# Altium schematic sheet schematic_B.SchDoc(schematic_B)
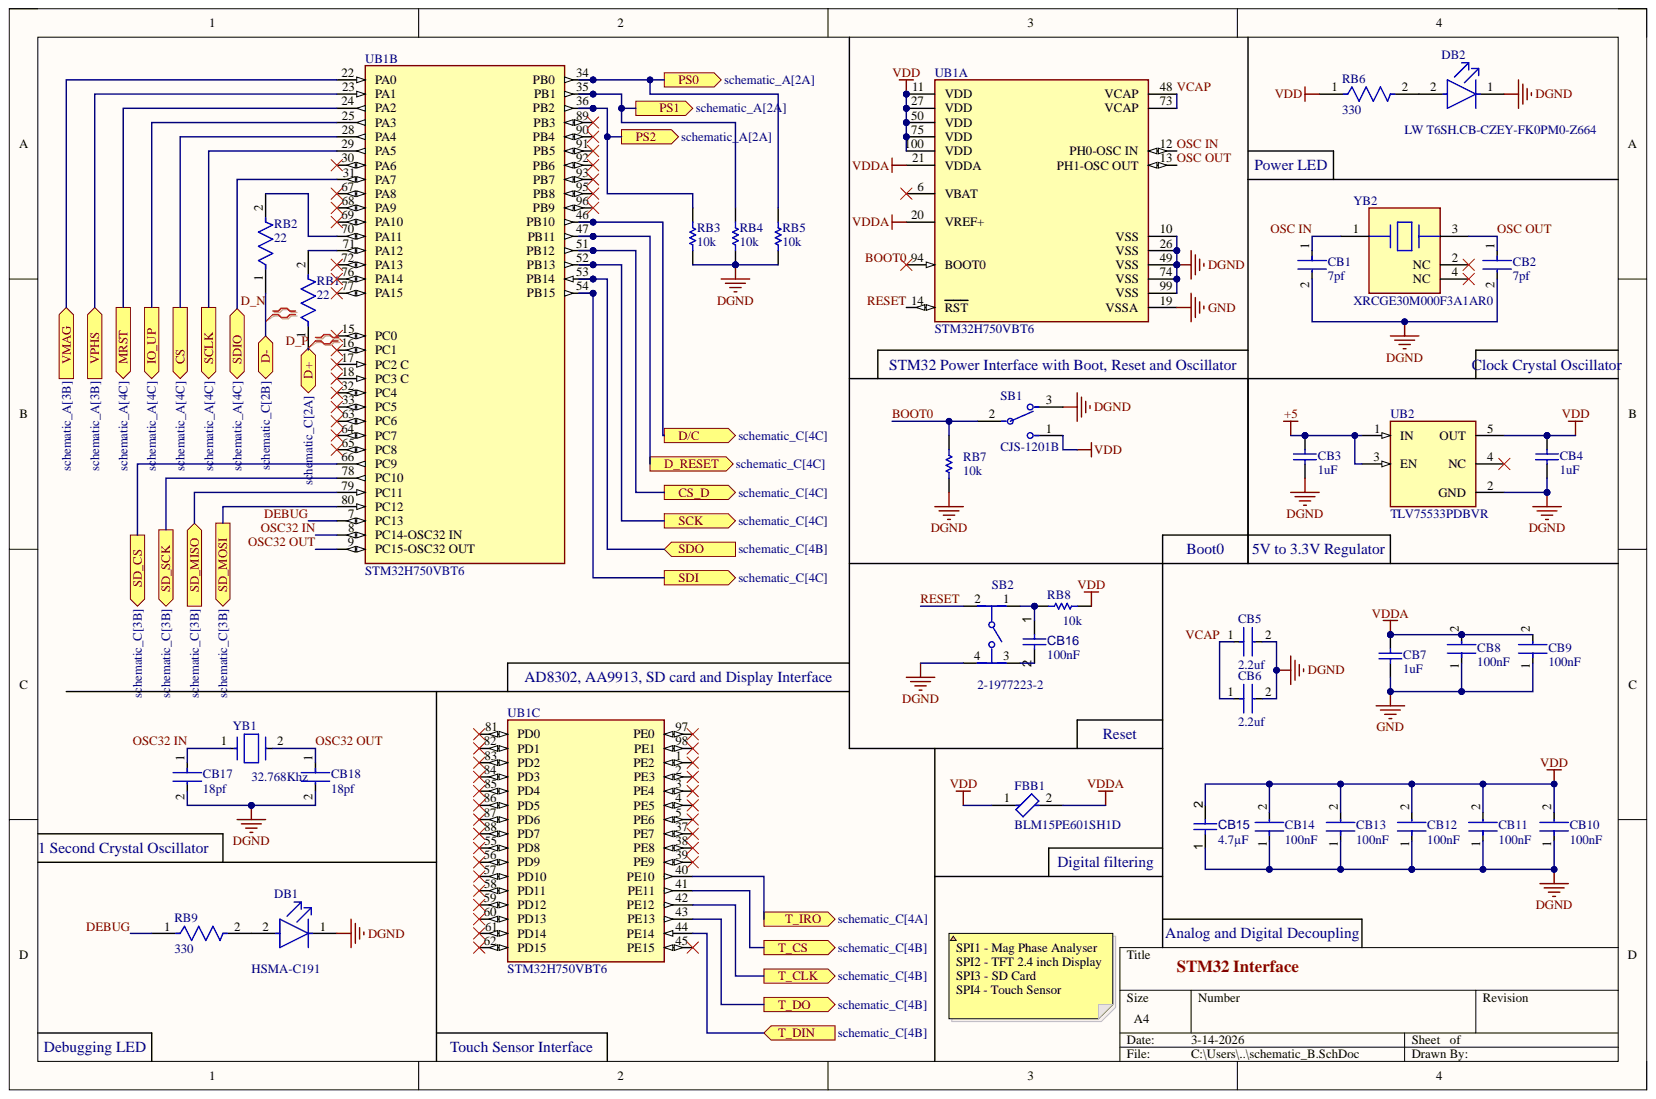
[diagram p0: schematic_B.SchDoc]

## Parts
CB1  7pf  [FP-GRM033-0_03-IPC_B]
CB2  7pf  [FP-GRM033-0_03-IPC_B]
CB3  1uF  [CAPC0603X33X15NL03T05]
CB4  1uF  [CAPC0603X33X15NL03T05]
CB5  2.2uf  [FP-GRM155-0_2-IPC_B]
CB6  2.2uf  [FP-GRM155-0_2-IPC_B]
CB7  1uF  [CAPC0603X33X15NL03T05]
CB8  100nF  [FP-0402-L_1_0_0_05-W_0_5-MFG]
CB9  100nF  [FP-0402-L_1_0_0_05-W_0_5-MFG]
CB10  100nF  [FP-0402-L_1_0_0_05-W_0_5-MFG]
CB11  100nF  [FP-0402-L_1_0_0_05-W_0_5-MFG]
CB12  100nF  [FP-0402-L_1_0_0_05-W_0_5-MFG]
CB13  100nF  [FP-0402-L_1_0_0_05-W_0_5-MFG]
CB14  100nF  [FP-0402-L_1_0_0_05-W_0_5-MFG]
CB15  value 4.7µF  [CAPC0805(2012)145_L]  (hidden comment: Capacitor 4.7µF +/-40% 25V 0805)
CB16  value 100nF  [CAPC0402(1005)60_L]  (hidden comment: Capacitor 100nF +/-20% 25V 0402)
CB17  18pf  [FP-GRM033-0_03-IPC_A]
CB18  18pf  [FP-GRM033-0_03-IPC_A]
DB1  HSMA-C191  [FP-HSMA-C191-MFG]
DB2  LW T6SH.CB-CZEY-FK0PM0-Z664  [FP-LW_T6SH_CB-CZEY-FK0PM0-MFG]
FBB1  BLM15PE601SH1D  [FP-BLM15-0_05-MFG]
RB1  22  [FP-RMCF0402-MFG]
RB2  22  [FP-RMCF0402-MFG]
RB3  10k  [RESC1005X40X25LL10T10]
RB4  10k  [RESC1005X40X25LL10T10]
RB5  10k  [RESC1005X40X25LL10T10]
RB6  330  [FP-RMCF0805-IPC_C]
RB7  10k  [RESC1005X40X25LL10T10]
RB8  10k  [RESC1005X40X25LL10T10]
RB9  330  [FP-RMCF0805-IPC_C]
SB1  CJS-1201B  [CJS-1201B_MFG]
SB2  2-1977223-2  [FP-2-1977223-2-MFG]
UB1  STM32H750VBT6  [FP-LQFP100-1L-MFG]  (parts A,B,C)
UB2  TLV75533PDBVR  [FP-DBV0005A-MFG]
YB1  32.768Khz  [FP-ABS09-MFG]
YB2  XRCGE30M000F3A1AR0  [FP-XRCGE-F-A_nbr-MFG]

## Ports
CS, CS_D, D+, D-, D/C, D_RESET, IO_UP, MRST, PS0, PS1, PS2, SCK, SCLK, SD_CS, SD_MISO, SD_MOSI, SD_SCK, SDI, SDIO, SDO, T_CLK, T_CS, T_DIN, T_DO, T_IRO, VMAG, VPHS

## Nets
+5: CB3.1 UB2.1(IN) UB2.3(EN)
DGND: CB1.2 CB2.2 CB3.2 CB4.2 CB5.2 CB6.2 CB10.1 CB11.1 CB12.1 CB13.1 CB14.1 CB15.1 CB17.2 CB18.2 DB1.1 DB2.1 RB3.2 RB4.2 RB5.2 RB7.1 SB1.3 SB2.4 UB1.10(VSS) UB1.26(VSS) UB1.49(VSS) UB1.74(VSS) UB1.99(VSS) UB2.2(GND)
GND: CB7.2 CB8.1 CB9.1 UB1.19(VSSA)
VDD: CB4.1 CB10.2 CB11.2 CB12.2 CB13.2 CB14.2 CB15.2 FBB1.1 RB6.1 RB8.1 SB1.1 UB1.11(VDD) UB1.27(VDD) UB1.50(VDD) UB1.75(VDD) UB1.100(VDD) UB2.5(OUT)
VDDA: CB7.1 CB8.2 CB9.2 FBB1.2 UB1.20(VREF+) UB1.21(VDDA)
BOOT0: RB7.2 SB1.2 UB1.94(BOOT0)
CS: UB1.28(PA4) ; port CS
CS_D: UB1.51(PB12) ; port CS_D
D/C: UB1.46(PB10) ; port D/C
D_N: RB2.1
D_P: RB1.1
D_RESET: UB1.47(PB11) ; port D_RESET
DEBUG: RB9.1 UB1.7(PC13)
IO_UP: UB1.25(PA3) ; port IO_UP
MRST: UB1.24(PA2) ; port MRST
OSC32 IN: CB17.1 UB1.8(PC14-OSC32_IN) YB1.1
OSC32 OUT: CB18.1 UB1.9(PC15-OSC32_OUT) YB1.2
OSC IN: CB1.1 UB1.12(PH0-OSC_IN) YB2.1
OSC OUT: CB2.1 UB1.13(PH1-OSC_OUT) YB2.3
PS0: RB5.1 UB1.34(PB0) ; port PS0
PS1: RB4.1 UB1.35(PB1) ; port PS1
PS2: RB3.1 UB1.36(PB2) ; port PS2
RESET: SB2.2 UB1.14(RST)
SCK: UB1.52(PB13) ; port SCK
SCLK: UB1.29(PA5) ; port SCLK
SD_CS: UB1.66(PC9) ; port SD_CS
SD_MISO: UB1.79(PC11) ; port SD_MISO
SD_MOSI: UB1.80(PC12) ; port SD_MOSI
SD_SCK: UB1.78(PC10) ; port SD_SCK
SDI: UB1.54(PB15) ; port SDI
SDIO: UB1.31(PA7) ; port SDIO
SDO: UB1.53(PB14) ; port SDO
T_CLK: UB1.42(PE12) ; port T_CLK
T_CS: UB1.41(PE11) ; port T_CS
T_DIN: UB1.44(PE14) ; port T_DIN
T_DO: UB1.43(PE13) ; port T_DO
T_IRO: UB1.40(PE10) ; port T_IRO
VCAP: CB5.1 CB6.1 UB1.48(VCAP) UB1.73(VCAP)
VMAG: UB1.22(PA0) ; port VMAG
VPHS: UB1.23(PA1) ; port VPHS
NetCB16_1: CB16.1 RB8.2 SB2.1
NetCB16_2: CB16.2 SB2.3
NetDB1_2: DB1.2 RB9.2
NetDB2_2: DB2.2 RB6.2
NetRB1_2: RB1.2 UB1.71(PA12)
NetRB2_2: RB2.2 UB1.70(PA11)

Not connected: UB1 1(PE2) 2(PE3) 3(PE4) 4(PE5) 5(PE6) 6(VBAT) 15(PC0) 16(PC1) 17(PC2_C) 18(PC3_C) 30(PA6) 32(PC4) 33(PC5) 37(PE7) 38(PE8) 39(PE9) 45(PE15) 55(PD8) 56(PD9) 57(PD10) 58(PD11) 59(PD12) 60(PD13) 61(PD14) 62(PD15) 63(PC6) 64(PC7) 65(PC8) 67(PA8) 68(PA9) 69(PA10) 72(PA13) 76(PA14) 77(PA15) 81(PD0) 82(PD1) 83(PD2) 84(PD3) 85(PD4) 86(PD5) 87(PD6) 88(PD7) 89(PB3) 90(PB4) 91(PB5) 92(PB6) 93(PB7) 95(PB8) 96(PB9) 97(PE0) 98(PE1); UB2 4(NC); YB2 2(NC) 4(NC)

## Library data of the parts
CB1 CB2 = CMP-2010-01441-2: Chip Multilayer Ceramic Capacitors for General Purpose, 0201, 7.0pF, C0G, 30ppm/°C, 0.1pF, 25V; Capacitance: 7pF; Case Code (Imperial): 0201; Case Code (Metric): 0603; Case/Package: 0201; Component Kind: Standard; Dielectric: C0G; Height: 0.3mm; Length: 0.024inch; Library Name: Altium Content Vault; Max Operating Temperature: 125°C; Min Operating Temperature: -55°C; Mount: Surface Mount; Package Quantity: 50000; Packaging: Tape and Reel; Termination: SMD/SMT; Thickness: 0.013inch; Voltage Rating: 25V; Voltage Rating (DC): 25V; Weight: 6E-06oz; Width: 0.012inch
CB3 CB4 CB7 = CMP-2010-00160-1: Manufacturer: AVX Interconnect / Elco; Part Number: 02016D105KAT2A; Component Kind: Standard; Library Name: Altium Content Vault
CB5 CB6 = CMP-06035-053544-1: Chip Multilayer Ceramic Capacitors for General Purpose, 0402, 2.2uF, X7R, 15%, 10%, 10V; Component Kind: Standard; Library Name: Altium Content Vault
CB8-CB14 = CMP-14477-003271-3: Ceramic Capacitor General Use 0.1uF ±10% 25V X5R 0402; NexarPartId: 49252556; Capacitance: 100nF; Case/Package: 0402; Component Kind: Standard; Halogen Free: Halogen Free; Height: 550µm; Length: 990.6µm; Library Name: Altium Content Vault; Material: Ceramic; Max Length: 1.05mm; Max Operating Temperature: 85°C; Max Thickness: 550µm; Max Width: 550µm; Min Length: 950µm; Min Operating Temperature: -55°C; Min Thickness: 450µm; Min Width: 450µm; Mount: Surface Mount; Packaging: Tape & Reel (TR); RoHS: Compliant; Thickness: 558.8µm; Tolerance: 10%; Voltage Rating: 25V; Voltage Rating (DC): 25V; Width: 508µm
CB15 = CMP-001-00080-4: Chip Capacitor, 4.7µF +/-40%, 25V, 0805, Thickness 1.45 mm; Tolerance: 20%; Case/Package: 0805; Component Kind: Standard; Library Name: Test; Max Operating Temperature: 85°C; Min Operating Temperature: -55°C; Mounting Technology: SMT; Pins: 2; Voltage Rating: 25V
CB16 = CMP-001-00065-4: Chip Capacitor, 100nF +/-20%, 25V, 0402, Thickness 0.6 mm; Tolerance: 10%; Case/Package: 0402; Component Kind: Standard; Library Name: Test; Max Operating Temperature: 85°C; Min Operating Temperature: -55°C; Mounting Technology: SMT; Pins: 2; Voltage Rating: 25V
CB17 CB18 = CMP-06035-001884-1: Chip Multilayer Ceramic Capacitors for General Purpose, 0201, 18pF, C0G, 30ppm/°C, 1%, 25V; Capacitance: 18pF; Case Code (Imperial): 0201; Case Code (Metric): 0603; Case/Package: 0201; Component Kind: Standard; Dielectric: C0G; Height: 0.3mm; Length: 0.024inch; Library Name: Altium Content Vault; Max Operating Temperature: 125°C; Min Operating Temperature: -55°C; Mount: Surface Mount; Package Quantity: 15000; Packaging: Tape and Reel; Termination: SMD/SMT; Thickness: 0.013inch; Tolerance: 1%; Voltage Rating: 25V; Voltage Rating (DC): 25V; Width: 0.3mm
DB1 = CMP-02129-71131210-1: HSMA-C191 LED Low-Power Uni-Color Amber 595nm 1.9V 2-Pin Chip LED T/R; Dominant Wavelength: 592nm; Forward Current: 20mA; Illumination Color: Orange; Lens Color: Clear, White; Lens Style: Diffused; Luminous Intensity: 90mcd; Max Junction Temperature (Tj): 95°C; Number of Elements: 1; Power Dissipation: 60mW; Reverse Voltage: 5V; Viewing Angle: 170°; Case Code (Imperial): 0603; Case Code (Metric): 1608; Case/Package: 0603; Color: Orange; Component Kind: Standard; Depth: 800µm; Forward Voltage: 1.9V; Height: 600µm; Lead Free: Lead Free; Length: 1.6mm; Lens Transparency: Diffused; Library Name: Altium Content Vault; Max Operating Temperature: 85°C; Min Operating Temperature: -40°C; Mount: Surface Mount; NexarPartId: 71131210; Number of LEDs: 1; Number of Pins: 2; Packaging: Tape and Reel; Peak Wavelength: 595nm; Power Rating: 60mW; REACH SVHC: No SVHC; RoHS: Compliant; Test Current: 20mA; Voltage Rating (DC): 1.9V; Wavelength: 592nm; Width: 800µm
DB2 = CMP-62016-000075-1: TOPLED; Component Kind: Standard; Library Name: Altium Content Vault
FBB1 = CMP-00260-117051428-1: BLM15PE601SH1D Ferrite Bead, 600 Ohm, 25%, 0402 Rohs Compliant: Yes; Resistance: 400mΩ; RMS Current (Irms): 700mA; Test Frequency: 100MHz; Case/Package: 0402; Component Kind: Standard; Core Material: Ferrite; Height: 550µm; Library Name: Altium Content Vault; Lifecycle Status: Production; Max Operating Temperature: 125°C; Min Operating Temperature: -55°C; NexarPartId: 117051428; Series Resistance: 400mΩ; Tolerance: 25%
RB1 RB2 = CMP-26527-006952-1: 22Ω ±1% 0.063W 0402 Thick Film Chip Resistor AEC-Q200 compliant; Max Power Dissipation: 63mW; Resistance: 22R; Temperature Coefficient: 100ppm/°C; Case/Package: 0402; Component Kind: Standard; Contact Plating: Tin; Features: Automotive AEC-Q200; Height: 0.016inch; Length: 0.039inch; Library Name: Altium Content Vault; Max Operating Temperature: 155°C; Min Operating Temperature: -55°C; Mount: Surface Mount; Number of Terminations: 2; Packaging: Tape and Reel; Resistor Type: Thick Film; RoHS Compliant: Yes; Series: RMCF; Tolerance: 1%; Width: 0.02inch
RB3-RB5 RB7 RB8 = CMP-2002-04584-1: Manufacturer: KOA Speer; Part Number: SG73P1ETTP1002F; Component Kind: Standard; Library Name: Altium Content Vault
RB6 RB9 = CMP-26527-004125-1: 330Ω ±5% 0.125W 0805 Thick Film Chip Resistor AEC-Q200 compliant; Max Power Dissipation: 125mW; Resistance: 330R; Temperature Coefficient: 200ppm/°C; Case Code (Imperial): 0805; Case Code (Metric): 2012; Case/Package: 0805; Component Kind: Standard; Construction: Rectangular; Contact Plating: Tin; Depth: 1.25mm; Features: Automotive AEC-Q200; Height: 0.026inch; Lead Free: Lead Free; Length: 2mm; Library Name: Altium Content Vault; Max Operating Temperature: 155°C; Military Standard: Not; Min Operating Temperature: -55°C; Mount: Surface Mount; Number of Terminations: 2; Packaging: Tape and Reel; Power Rating: 125mW; Radiation Hardening: No; Resistor Type: Thick Film; RoHS Compliant: Yes; Series: RMCF; Size Code: 0805; Tolerance: 5%; Width: 0.049inch
SB1 = CMP-08360-85278913-1: Slide Switch, SPDT, 100mA, 6V, Flush, Surface Mount, CJS Series; Actuator Material: Polyamide; Contact Resistance: 100mΩ; Life (Cycles): 200cycles; Max Voltage Rating (DC): 24V; Number of Circuits: 1; Number of Positions: 3; Case/Package: SMD/SMT; Component Kind: Standard; ComponentLink2Description: Datasheet URL; ComponentLink2URL: https://www.nidec-components.com/e/catalog/switch/cjs.pdf; Contact Current Rating: 100mA; Contact Material: Gold; Contact Plating: Gold; Height: 2.5mm; HelpURL: https://www.nidec-components.com/e/catalog/switch/cjs.pdf; Library Name: Altium Content Vault; Max Operating Temperature: 85°C; Min Operating Temperature: -40°C; Mount: Surface Mount; Packaging: Bulk; Radiation Hardening: No; RoHS: Compliant; Termination: Gull Wing; Throw Configuration: SPDT; Voltage Rating (DC): 6V
SB2 = CMP-03407-000026-1: SWITCH TACTILE SPST-NO 0.05A 24V; Component Kind: Standard; Library Name: Altium Content Vault; RoHS Compliant: Yes
UB1 = CMP-12105-000017-1: IC MCU 32BIT 128KB FLASH 100LQFP; Manufacturer: STMicroelectronics; Core Architecture: ARM Cortex-M7; Data Bus Width: 32bits; Frequency: 480MHz; Interface: I2C,SPI,UART,USART,CAN,USB,LIN,IrDA,Ethernet,I2S,Other; Max Junction Temp: +125°C; Max Supply Voltage: 3.6V; Memory Size: 128KBytes; Min Supply Voltage: 1.62V; Number Of A/D Converters: 3; Number Of Adc Channels: 36; Number Of Cores: 1; Number Of I2C Channels: 4; Number Of I/Os: 82; Number Of Pwm Channels: 42; Number Of Spi Channels: 6; Number Of Timers/Counters: 20; Number Of Uart Channels: 9; Number Of Usb Channels: 2; Ram Size: 1MBytes; Standoff Height: 0.05mm; Ambient Temperature Range High: +85°C; Ambient Temperature Range Low: -40°C; Automotive: No; Category: IC; Component Kind: Standard; ComponentLink2Description: Datasheet; ComponentLink2URL: https://www.st.com/resource/en/datasheet/stm32h750vb.pdf; Device Class L1: Integrated Circuits (ICs); Device Class L2: Embedded Processors and Controllers; Device Class L3: Microcontrollers; Digikey Description: IC MCU 32BIT 128KB FLASH 100LQFP; Height: 1.6mm; Lead Free: Yes; Library Name: Altium Content Vault; Manufacturer Part Number: STM32H750VBT6; Memory Type: FLASH; Mouser Description: MCU 32-Bit STM32H750VB ARM Cortex M7 RISC 128KB Flash 1.8V/2.5V/3.3V 100-Pin LQFP Tray; Number Of Ethernet Channels: 1; Octopart: https://octopart.com/stm32h750vbt6-stmicroelectronics-94048547; Package: LQFP100-1L; RoHS: TRUE; Temperature: -40°C to +125°C; Temperature Range High: +125°C; Temperature Range Low: -40°C
UB2 = CMP-04917-000010-1: IC REG LINEAR 3.3V 500MA SOT23-5; Manufacturer: Texas Instruments; Accuracy Percentage: 1%; Dropout Voltage: 150mV; Max Junction Temp: +125°C; Max Supply Voltage: 5.5V; Min Supply Voltage: 1.45V; Number Of Outputs: 1; Output Type: Fixed; Standoff Height: 0mm; Ambient Temperature Range High: +70°C; Automotive: No; Category: IC; Component Kind: Standard; ComponentLink2Description: Datasheet; ComponentLink2URL: http://www.ti.com/lit/ds/symlink/tlv755p.pdf; Device Class L1: Integrated Circuits (ICs); Device Class L2: Power Management ICs; Device Class L3: Voltage Regulators - Linear; Digikey Description: IC REG LINEAR 3.3V 500MA SOT23-5; Height: 1.45mm; Lead Free: Yes; Library Name: Altium Content Vault; Manufacturer Part Number: TLV75533PDBVR; Mouser Description: 500-mA low-IQ small-size low-dropout (LDO) regulator 5-SOT-23 -40 to 125; Octopart: https://octopart.com/tlv75533pdbvr-texas+instruments-91272349; Output Current: 500mA; Output Voltage: 3.3V; Package: DBV0005A; RoHS: TRUE; Temperature: -40°C to +125°C; Temperature Range High: +125°C; Temperature Range Low: -40°C
YB1 = CMP-27762-005385-1: CRYSTAL 32.7680KHZ 12.5PF SMD; ESR (Equivalent Series Resistance): 70kR; Frequency Tolerance: 10ppm; Case/Package: CSMD; Component Kind: Standard; Depth: 1.5mm; Frequency: 32.768kHz; Height: 0.035inch; Lead Free: Lead Free; Length: 4.1mm; Library Name: Altium Content Vault; Load Capacitance: 12.5pF; Max Operating Temperature: 85°C; Min Operating Temperature: -40°C; Mount: Surface Mount; Number of Pins: 2; Operating Frequency: 32.768 kHz; Package Quantity: 3000; Packaging: Tape and Reel; RoHS Compliant: Yes; Series: ABS09; Series Resistance: 70kR; Termination: SMD/SMT; Tolerance: 10ppm; Width: 1.5mm
YB2 = CMP-06054-000025-1: 30 MHz ±45ppm Crystal 6pF 70 Ohms 4-SMD, No Lead; Component Kind: Standard; Library Name: Altium Content Vault
